# Altium schematic sheet MCU IO and RTC.SchDoc
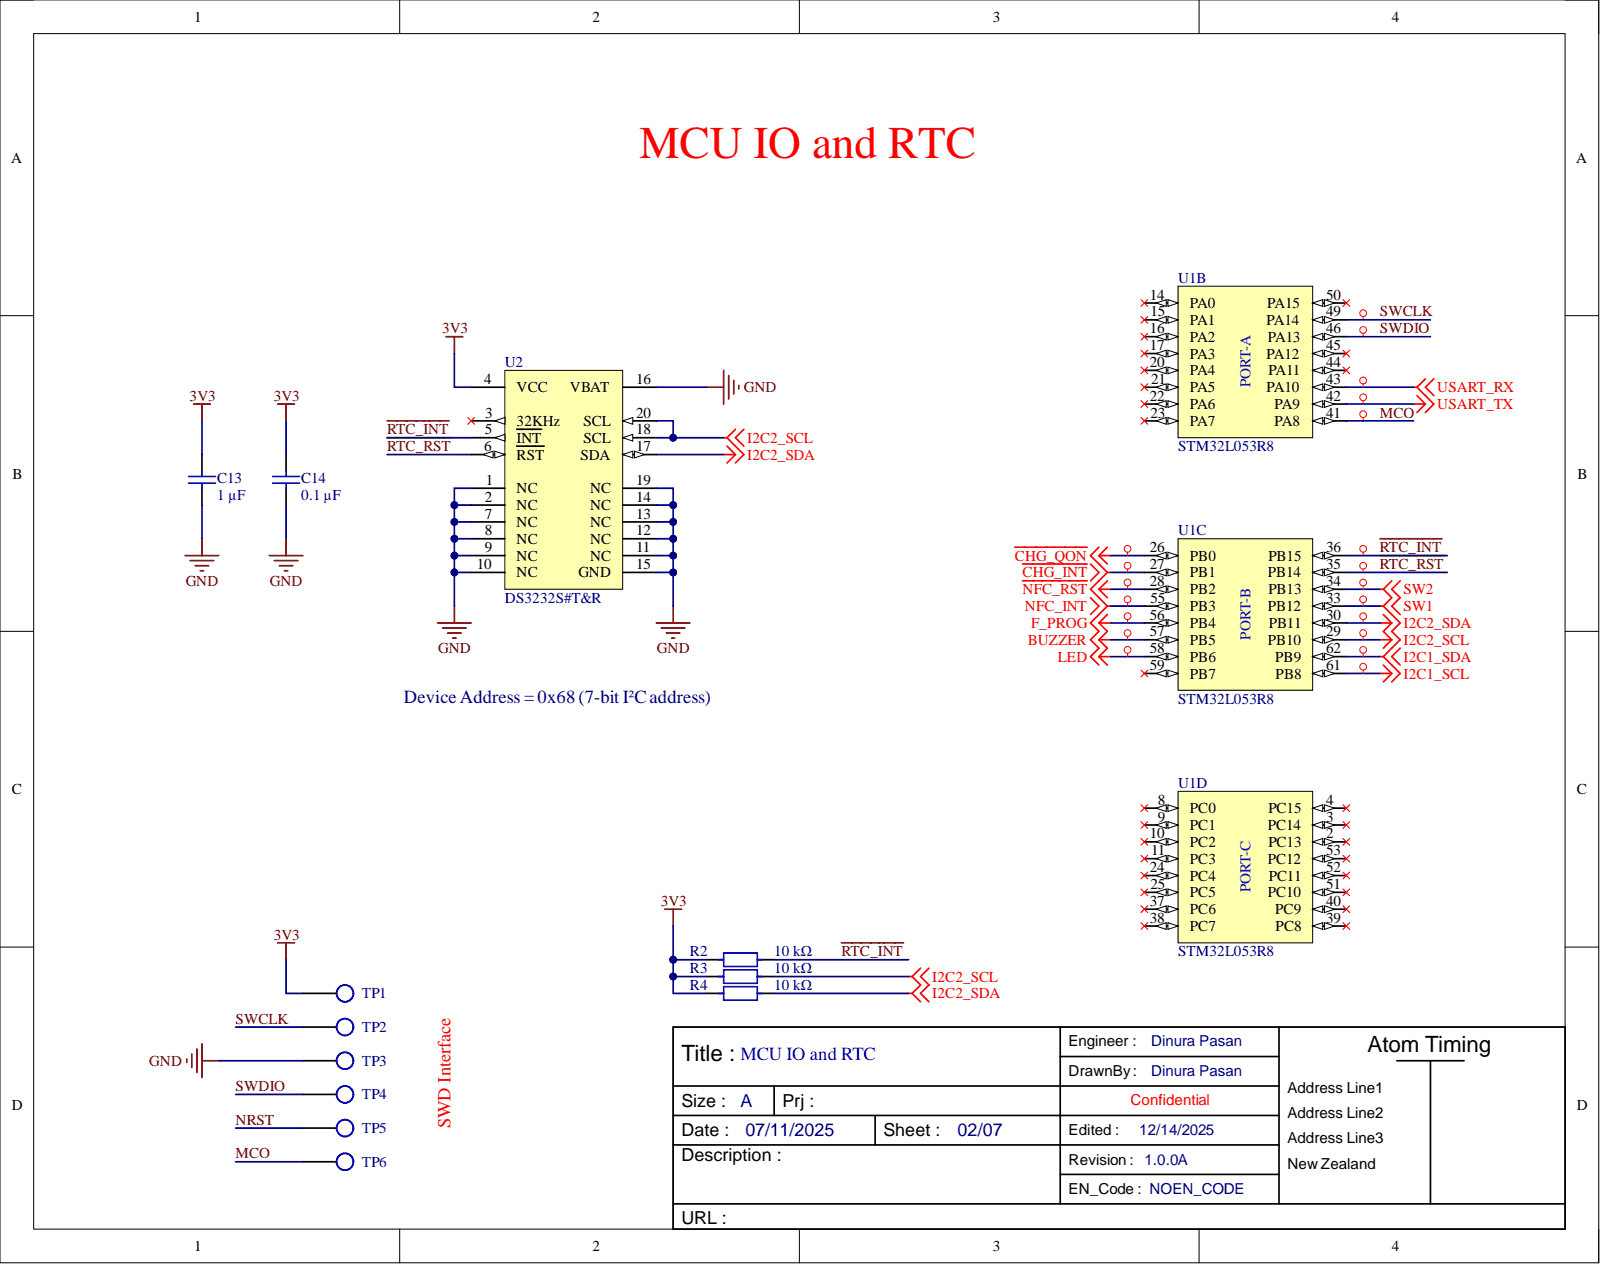
[diagram p0: MCU IO and RTC.SchDoc]

## Parts
C13  1 µF  [TDK_CGA0805N]
C14  0.1 µF  [TDK_CGA0805N]
R2  10 kΩ  [Panasonic_ERA2AN]
R3  10 kΩ  [Panasonic_ERA2AN]
R4  10 kΩ  [Panasonic_ERA2AN]
TP1  TestPoint  [TESTPOINT]  (value not drawn)
TP2  TestPoint  [TESTPOINT]  (value not drawn)
TP3  TestPoint  [TESTPOINT]  (value not drawn)
TP4  TestPoint  [TESTPOINT]  (value not drawn)
TP5  TestPoint  [TESTPOINT]  (value not drawn)
TP6  TestPoint  [TESTPOINT]  (value not drawn)
U1  STM32L053R8  [ST-LQFP64]  (parts B,C,D)
U2  DS3232S#T&R  [DS3232S#T&R]

## Nets
3V3: C13.2 C14.2 R2.1 R3.1 R4.1 TP1.1 U2.4(VCC)
BUZZER: U1.57(PB5)
~{CHG_INT}: U1.27(PB1)
~{CHG_QON}: U1.26(PB0)
F_PROG: U1.56(PB4)
GND: C13.1 C14.1 TP3.1 U2.1(NC) U2.2(NC) U2.7(NC) U2.8(NC) U2.9(NC) U2.10(NC) U2.11(NC) U2.12(NC) U2.13(NC) U2.14(NC) U2.15(GND) U2.16(VBAT) U2.19(NC)
I2C1_SCL: U1.61(PB8)
I2C1_SDA: U1.62(PB9)
I2C2_SCL: R3.2 U1.29(PB10) U2.18(SCL) U2.20(SCL)
I2C2_SDA: R4.2 U1.30(PB11) U2.17(SDA)
LED: U1.58(PB6)
~{NFC_RST}: U1.28(PB2)
NFC_INT: U1.55(PB3)
SW1: U1.33(PB12)
SW2: U1.34(PB13)
USART_RX: U1.43(PA10)
USART_TX: U1.42(PA9)
MCO: TP6.1 U1.41(PA8)
NRST: TP5.1
~{RTC_INT}: R2.2 U1.36(PB15) U2.5(INT)
~{RTC_RST}: U1.35(PB14) U2.6(RST)
SWCLK: TP2.1 U1.49(PA14)
SWDIO: TP4.1 U1.46(PA13)

Not connected: U1 2(PC13) 3(PC14) 4(PC15) 8(PC0) 9(PC1) 10(PC2) 11(PC3) 14(PA0) 15(PA1) 16(PA2) 17(PA3) 20(PA4) 21(PA5) 22(PA6) 23(PA7) 24(PC4) 25(PC5) 37(PC6) 38(PC7) 39(PC8) 40(PC9) 44(PA11) 45(PA12) 50(PA15) 51(PC10) 52(PC11) 53(PC12) 59(PB7); U2 3(32KHz)

## Library data of the parts
C13 = CGA4J3X7R1H105K125AB: 1 µF ±10% 50V X7R -55°C ~ 125°C 0805 (2012 Metric); Manufacturer: TDK Corporation; Database Table Name: Sheet1$; Eco Plan: RoHS & Green; Initial Voltage: 0V; TEMP: 25; Value: 1u; Capacitance: 1 µF; Category: Ceramic Capacitor (MLCC); Component Kind: Standard; Datasheet: https://product.tdk.com/system/files/dam/doc/product/capacitor/ceramic/mlcc/catalog/mlcc_automotive_general_en.pdf; Library Name: MLCC.DbLib; Manufacturer Part Number: CGA4J3X7R1H105K125AB; Operating Temperature: -55°C ~ 125°C; Package / Case: 0805 (2012 Metric); Ratings: AEC-Q200; Series: CGA; Simulation: C_Capacitor_Default; Size / Dimension: 0.079" L x 0.049" W (2.00mm x 1.25mm); Supplier 1: Digi-Key; Supplier Part Number 1: 445-6961-2-ND; Temperature Coefficient: X7R; Thickness (Max): 0.059" (1.50mm); Tolerance: ±10%; Voltage - Rated: 50V
C14 = CGA4J2X7R2A104K125AE: 0.1 µF ±10% 100V X7R -55°C ~ 125°C 0805 (2012 Metric); Manufacturer: TDK Corporation; Database Table Name: Sheet1$; Eco Plan: RoHS & Green; Initial Voltage: 0V; TEMP: 25; Value: 0.1u; Capacitance: 0.1 µF; Category: Ceramic Capacitor (MLCC); Component Kind: Standard; Datasheet: https://product.tdk.com/system/files/dam/doc/product/capacitor/ceramic/mlcc/catalog/mlcc_automotive_general_en.pdf; Library Name: MLCC.DbLib; Manufacturer Part Number: CGA4J2X7R2A104K125AE; Operating Temperature: -55°C ~ 125°C; Package / Case: 0805 (2012 Metric); Ratings: AEC-Q200; Series: CGA; Simulation: C_Capacitor_Default; Size / Dimension: 0.079" L x 0.049" W (2.00mm x 1.25mm); Supplier 1: Digi-Key; Supplier Part Number 1: 445-15961-2-ND; Temperature Coefficient: X7R; Thickness (Max): 0.059" (1.50mm); Tolerance: ±10%; Voltage - Rated: 100V
R2-R4 = ERA-2ARB103X: 10 kΩ ±0.1% 0.063W, 1/16W Thin Film 0402 (1005 Metric) 50V ±10ppm/°C -55°C ~ 155°C; Manufacturer: Panasonic Electronic Components; Database Table Name: Sheet1$; Eco Plan: RoHS & Green; Maximum Overload Voltage: 100V; Rated Voltage: √(PxR); TC: 10; TC1: 0; TEMP: 25; Value: 10kΩ; Category: SMD Thin Film Resistor; Component Kind: Standard; Composition: Thin Film; Datasheet: https://industrial.panasonic.com/cdbs/www-data/pdf/RDM0000/AOA0000C307.pdf; Height - Seated (Max): 0.016" (0.40mm); Library Name: SMD_Thin_Film_Resistors.DbLib; Manufacturer Part Number: ERA-2ARB103X; Maximum Working Voltage: 50V; Operating Temperature: -55°C ~ 155°C; Package / Case: 0402 (1005 Metric); Power (Watts): 0.063W, 1/16W; Ratings: AEC-Q200; Resistance: 10 kΩ; Series: ERA; Simulation: R_Resistor_Default; Size / Dimension: 0.039" L x 0.020" W (1.00mm x 0.50mm); Supplier 1: Digi-Key; Supplier Part Number 1: P10KCPTR-ND; TC2: 0; Temperature Coefficient: ±10ppm/°C; Tolerance: ±0.1%
TP1-TP6 = TestPoint: 1mm Test Point; Component Kind: Standard; Library Name: Common_library.IntLib
U1 = STM32L053R8: Ultra-low-power Arm Cortex-M0+ MCU with 64 Kbytes of Flash memory, 32 MHz CPU, USB, LCD; Manufacturer 1: STMicroelectronics; Manufacturer Part Number 1: STM32L053R8T6D; ADC Channels (Resolution): 16-Ch 12-Bit; Case/Package 1: LQFP; Category 1: Microcontrollers; DAC Channels (Resolution): 1-Ch 12-Bit; Description 1: Ultra-low-power ARM Cortex-M0+ MCU with 64 Kbytes Flash, 32 MHz CPU, USB, LCD; EEPROM Memory Size: 2kB; Flash Memory Size: 64kB; Frequency: 32MHz; Interface 1: I2S, UART, USB; Max Frequency: 25MHz; Max Supply Voltage 1: 3.6V; Min Supply Voltage 1: 1.65V; Nominal Supply Current: 290nA; Number of D/A Converters: 1; Number of I2C Channels: 2; Number of I/Os: 51; Number of Pins 1: 64; Number of Timers/Counters: 4; Operating Supply Voltage: 3V; Oscillator Type: Internal; Peripherals: LCD, POR; RAM Size: 8kB; China RoHS: Compliant; Component Kind: Standard; ComponentLink1Description: https://datasheet.octopart.com:443/STM32L053R8T6D-STMicroelectronics-datasheet-109682748.pdf?src-supplier=IHS; ComponentLink1URL: https://datasheet.octopart.com:443/STM32L053R8T6D-STMicroelectronics-datasheet-109682748.pdf?src-supplier=IHS; ComponentLink2Description: https://datasheet.octopart.com:443/STM32L053R8T6D-STMicroelectronics-datasheet-27724236.pdf?src-supplier=Upverter; ComponentLink2URL: https://datasheet.octopart.com:443/STM32L053R8T6D-STMicroelectronics-datasheet-27724236.pdf?src-supplier=Upverter; ComponentLink3Description: https://datasheet.octopart.com:443/STM32L053R8T6D-STMicroelectronics-datasheet-62336778.pdf?src-supplier=STMicroelectronics; ComponentLink3URL: https://datasheet.octopart.com:443/STM32L053R8T6D-STMicroelectronics-datasheet-62336778.pdf?src-supplier=STMicroelectronics; ComponentLink4Description: https://datasheet.octopart.com:443/STM32L053R8T6D-STMicroelectronics-datasheet-22146913.pdf?src-supplier=STMicroelectronics; ComponentLink4URL: https://datasheet.octopart.com:443/STM32L053R8T6D-STMicroelectronics-datasheet-22146913.pdf?src-supplier=STMicroelectronics; ComponentLink5Description: https://datasheet.octopart.com:443/STM32L053R8T6D-STMicroelectronics-datasheet-38781224.pdf?src-supplier=element14+APAC; ComponentLink5URL: https://datasheet.octopart.com:443/STM32L053R8T6D-STMicroelectronics-datasheet-38781224.pdf?src-supplier=element14+APAC; ComponentLink6Description: https://datasheet.octopart.com:443/STM32L053R8T6D-STMicroelectronics-datasheet-48218917.pdf?src-supplier=element14+APAC; ComponentLink6URL: https://datasheet.octopart.com:443/STM32L053R8T6D-STMicroelectronics-datasheet-48218917.pdf?src-supplier=element14+APAC; ComponentLink7Description: https://datasheet.octopart.com:443/STM32L053R8T6D-STMicroelectronics-datasheet-159009258.pdf?src-supplier=SHENGYU+ELECTRONICS; ComponentLink7URL: https://datasheet.octopart.com:443/STM32L053R8T6D-STMicroelectronics-datasheet-159009258.pdf?src-supplier=SHENGYU+ELECTRONICS; Core Architecture: ARM Cortex-M0+; Height - Seated (Max): 1.6mm; Ibis Model: stm32l0_51_52_53_62_63_lqfp64; Length: 10mm; Library Name: ICs.IntLib; Lifecycle Status: Production; Manufacturer Part Number 2: STM32L053R8T6TR; Manufacturer Part Number 3: STM32L053R8T3; Manufacturer Part Number 4: STM32L053R8T6; Manufacturer Part Number 5: STM32L053R8T7; Max Operating Temperature 1: 85°C; Memory Size: 64kB; Memory Type: FLASH; Min Operating Temperature 1: -40°C; Number of SPI Channels: 2; Number of Terminals: 64; Number of USART Channels: 2; REACH SVHC: Yes; RoHS 1: Compliant; Supplier 1: Arrow Electronics; Supplier 2: DigiKey; Supplier 3: Avnet; Supplier 4: Arrow Electronics; Supplier 5: Avnet; Supplier 6: DigiKey; Supplier 7: DigiKey; Supplier 8: DigiKey; Supplier 9: Mouser; Supplier 10: Future Electronics; Supplier 11: Newark; Supplier 12: Farnell; Supplier 13: Farnell; Supplier 14: Mouser; Supplier 15: DigiKey; Supplier 16: Avnet; Supplier 17: Arrow Electronics; Supplier 18: Farnell; Supplier 19: Arrow Electronics; Supplier 20: Avnet; Supplier 21: DigiKey; Supplier 22: Mouser; Supplier 23: Future Electronics; Supplier 24: Newark; Supplier 25: DigiKey; Supplier 26: Mouser; Supplier 27: Arrow Electronics; Supplier 28: Avnet; Supplier 29: Farnell; Supplier Part Number 1: STM32L053R8T6D; Supplier Part Number 2: STM32L053R8T6D-ND; Supplier Part Number 3: STM32L053R8T6D; Supplier Part Number 4: STM32L053R8T6TR; Supplier Part Number 5: STM32L053R8T6TR; Supplier Part Number 6: 497-19667-1-ND; Supplier Part Number 7: 497-19667-6-ND; Supplier Part Number 8: 497-19667-2-ND; Supplier Part Number 9: 511-STM32L053R8T6TR; Supplier Part Number 10: 5087979; Supplier Part Number 11: 54AH7029; Supplier Part Number 12: 3365459; Supplier Part Number 13: 3365459RL; Supplier Part Number 14: 511-STM32L053R8T3; Supplier Part Number 15: 497-18575-ND; Supplier Part Number 16: STM32L053R8T3; Supplier Part Number 17: STM32L053R8T3; Supplier Part Number 18: 2490881; Supplier Part Number 19: STM32L053R8T6; Supplier Part Number 20: STM32L053R8T6; Supplier Part Number 21: 497-14654-ND; Supplier Part Number 22: 511-STM32L053R8T6; Supplier Part Number 23: 6170600; Supplier Part Number 24: 28AC3380; Supplier Part Number 25: 497-17875-ND; Supplier Part Number 26: 511-STM32L053R8T7; Supplier Part Number 27: STM32L053R8T7; Supplier Part Number 28: STM32L053R8T7; Supplier Part Number 29: 2490883; Terminal Pitch: 500µm; Width: 10mm
U2 = DS3232S#T&R: Real Time Clock (RTC) IC Clock/Calendar 236B I2C, 2-Wire Serial 20-SOIC (0.295", 7.50mm Width); Manufacturer 1: Analog Devices; Manufacturer Lifecycle Status: PRODUCTION; Manufacturer Package Identifier: W20#H2; Case/Package 1: SOIC; Category 1: Real Time Clocks; Date Format: YY-MM-DD-dd; Description 1: DS3232 Series 5.5 V I2C 2-Wire Serial Surface Mount Real Time Clock - SOIC-20; Frequency: 32.768kHz; Mount 1: Surface Mount; Number of Pins 1: 20; Time Format: HH:MM:SS (12/24 hr); Component Kind: Standard; ComponentLink1Description: https://octopart.com:443/opatz8j6/c1?t=bNEuprDrg6f1PGMagWK02SfYNZlxZdhO31GUBXJw10RFbVDo6ACgX76FTq-DvKHCT-eSM8GmglmSr7Xfvoph8sVd4RyGjE3NPLtUKcVf6gmyy6EcaDc4hGerPg0tTRXxOP5AaIO0oqFu3_n8rxVWhcaeH1KfmIHo-_6G-oEXActOcV8juzqW-SGstTl2Imj1GaDLYxZx0sbjpZk7SBdAgU8dXIAODy8WQIHikVxTK6ymLaa0F3xbDDCq6dLKs7qLn5vv8ET2hRfJL0gJSDoONoe2ETWDMp4tvuwcXiyHToFbAzdGI6ZyJyU&src-supplier=Analog+Devices; ComponentLink1URL: https://octopart.com:443/opatz8j6/c1?t=bNEuprDrg6f1PGMagWK02SfYNZlxZdhO31GUBXJw10RFbVDo6ACgX76FTq-DvKHCT-eSM8GmglmSr7Xfvoph8sVd4RyGjE3NPLtUKcVf6gmyy6EcaDc4hGerPg0tTRXxOP5AaIO0oqFu3_n8rxVWhcaeH1KfmIHo-_6G-oEXActOcV8juzqW-SGstTl2Imj1GaDLYxZx0sbjpZk7SBdAgU8dXIAODy8WQIHikVxTK6ymLaa0F3xbDDCq6dLKs7qLn5vv8ET2hRfJL0gJSDoONoe2ETWDMp4tvuwcXiyHToFbAzdGI6ZyJyU&src-supplier=Analog+Devices; ComponentLink2Description: https://datasheet.octopart.com:443/DS3232S%23T%26R-Maxim-Integrated-datasheet-8885798.pdf?src-supplier=Upverter; ComponentLink2URL: https://datasheet.octopart.com:443/DS3232S%23T%26R-Maxim-Integrated-datasheet-8885798.pdf?src-supplier=Upverter; ComponentLink3Description: https://datasheet.octopart.com:443/DS3232S%23T%26R-Maxim-Integrated-datasheet-8630481.pdf?src-supplier=Upverter; ComponentLink3URL: https://datasheet.octopart.com:443/DS3232S%23T%26R-Maxim-Integrated-datasheet-8630481.pdf?src-supplier=Upverter; ComponentLink4Description: https://datasheet.octopart.com:443/DS3232S%23T%26R-Analog-Devices-datasheet-41200935.pdf?src-supplier=Future+Electronics; ComponentLink4URL: https://datasheet.octopart.com:443/DS3232S%23T%26R-Analog-Devices-datasheet-41200935.pdf?src-supplier=Future+Electronics; ComponentLink5Description: https://datasheet.octopart.com:443/DS3232S%23T%26R-Dallas-Semiconductor-datasheet-13718300.pdf?src-supplier=Augswan; ComponentLink5URL: https://datasheet.octopart.com:443/DS3232S%23T%26R-Dallas-Semiconductor-datasheet-13718300.pdf?src-supplier=Augswan; ComponentLink6Description: https://sigma.octopart.com:443/138896940/technical_drawing/Maxim-Integrated-DS3232S%23T%26R.pdf?src-supplier=ShanghaiCT; ComponentLink6URL: https://sigma.octopart.com:443/138896940/technical_drawing/Maxim-Integrated-DS3232S%23T%26R.pdf?src-supplier=ShanghaiCT; ComponentLink7Description: http://sigma.octopart.com:80/conflict_mineral_statement/Analog-Devices-company-33.pdf?src-supplier=Compliance+Statements; ComponentLink7URL: http://sigma.octopart.com:80/conflict_mineral_statement/Analog-Devices-company-33.pdf?src-supplier=Compliance+Statements; ComponentLink8Description: http://sigma.octopart.com:80/conflict_mineral_statement/Maxim-Integrated-company-65.pdf?src-supplier=Compliance+Statements; ComponentLink8URL: http://sigma.octopart.com:80/conflict_mineral_statement/Maxim-Integrated-company-65.pdf?src-supplier=Compliance+Statements; ComponentLink9Description: http://sigma.octopart.com:80/rohs_statement/Maxim-Integrated-company-66.pdf?src-supplier=Compliance+Statements; ComponentLink9URL: http://sigma.octopart.com:80/rohs_statement/Maxim-Integrated-company-66.pdf?src-supplier=Compliance+Statements; ComponentLink10Description: http://sigma.octopart.com:80/reach_statement/Maxim-Integrated-company-67.pdf?src-supplier=Compliance+Statements; ComponentLink10URL: http://sigma.octopart.com:80/reach_statement/Maxim-Integrated-company-67.pdf?src-supplier=Compliance+Statements; ComponentLink11Description: https://octopart.com:443/opatz8j6/c1?t=0abA4sLKsKSp-z__625CihNeUodpqX4MaGQ7x_B0JMGLlL14hbyOf3MTXWIrWaWgzBwbqs3gQLrLMOx_8wXrTfi4g80tTu-gf_HVg4eDRkl-AQ8nUwZLUbh7F85xONG3OgbtVVY14w7nZ2lFUTyuXvzZVLQodzMdZ3BrHDNXM_mXU2F-BjTS4GPH45xA0rZUFPypzjLZZ9210qbsAdyCQJSsBy6j64Bb_D65cC0BPeFX9RrajhKuYIN2kQkMD8NXVufL4GGNiDKLoxrme-3jXUVeOBjw54KVbjp3aOyZFDy2qO6mmJEC2a8&src-supplier=Compliance+Statements; ComponentLink11URL: https://octopart.com:443/opatz8j6/c1?t=0abA4sLKsKSp-z__625CihNeUodpqX4MaGQ7x_B0JMGLlL14hbyOf3MTXWIrWaWgzBwbqs3gQLrLMOx_8wXrTfi4g80tTu-gf_HVg4eDRkl-AQ8nUwZLUbh7F85xONG3OgbtVVY14w7nZ2lFUTyuXvzZVLQodzMdZ3BrHDNXM_mXU2F-BjTS4GPH45xA0rZUFPypzjLZZ9210qbsAdyCQJSsBy6j64Bb_D65cC0BPeFX9RrajhKuYIN2kQkMD8NXVufL4GGNiDKLoxrme-3jXUVeOBjw54KVbjp3aOyZFDy2qO6mmJEC2a8&src-supplier=Compliance+Statements; Contact Plating: Lead, Tin; Interface 1: I2C; Library Name: Components.IntLib; Lifecycle Status: Production; Manufacturer Part Number 1: DS3232S#T&R; Max Operating Temperature 1: 70°C; Memory Size: 236B; Min Operating Temperature 1: 0°C; Radiation Hardening: No; RoHS 1: Compliant; Schedule B: 8542390000|8542390000|8542390000|8542390000|8542390000|8542390000|8542390000|8542390000|8542390000|8542390000|8542390000|8542390000|8542390000|8542390000|8542390000|8542390000|8542390000|8542390000|8542390000|8542390000|8542390000|8542390000|8542390000|85; Supplier 1: DigiKey; Supplier Part Number 1: 175-DS3232S#T&RCT-ND
